# Altium schematic sheet DCDC_5V.SchDoc(DCDC_5V)
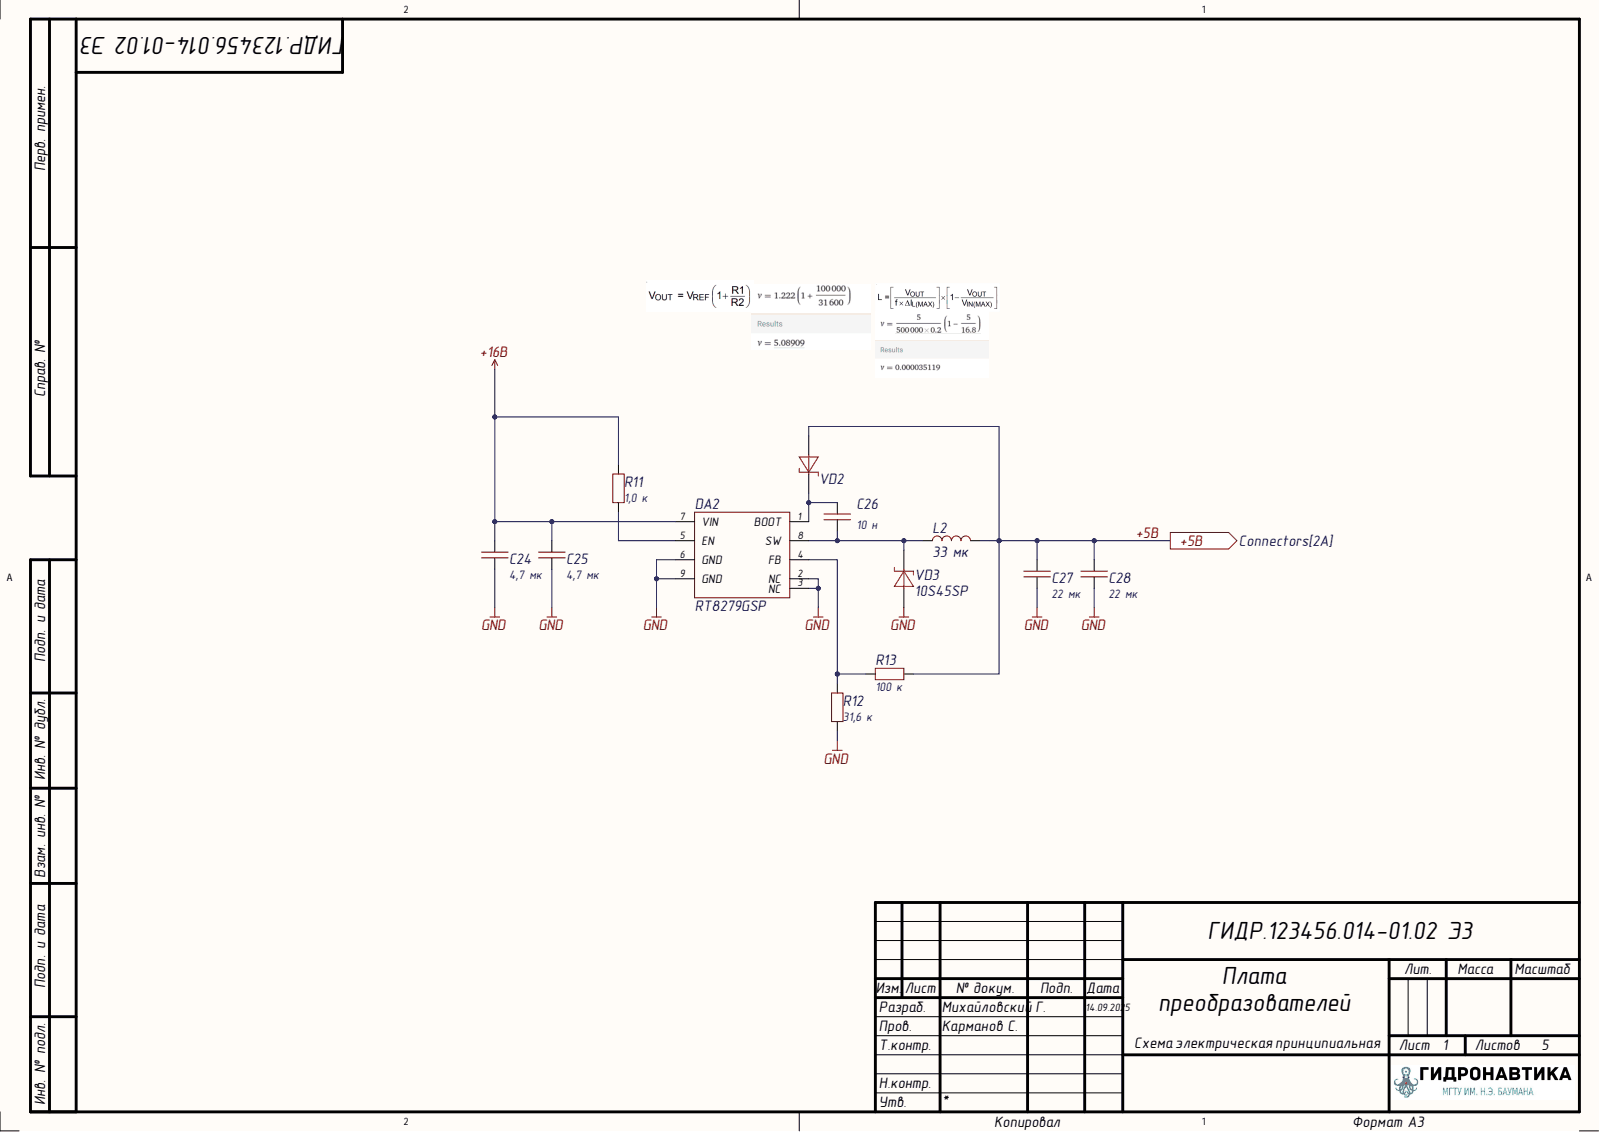
[diagram p0: DCDC_5V.SchDoc]

## Parts
C24  value 4,7 мк  [1206C]  (hidden comment: Capacitor)
C25  value 4,7 мк  [1206C]  (hidden comment: Capacitor)
C26  value 10 н  [0603C]  (hidden comment: Capacitor)
C27  value 22 мк  [1210C]  (hidden comment: Capacitor)
C28  value 22 мк  [1210C]  (hidden comment: Capacitor)
DA2  RT8279GSP  [8SOP(Exposed_Pad)]
L2  value 33 мк  [IHLP-4040]  (hidden comment: IHLP4040DZER330M11)
R11  value 1,0 к  [0603R]  (hidden comment: Resistor)
R12  value 31,6 к  [0603R]  (hidden comment: Resistor)
R13  value 100 к  [0603R]  (hidden comment: Resistor)
VD2  BAT54WS  [SOD-323]  (value not drawn)
VD3  10S45SP  [TO-277]

## Ports
+5В

## Nets
+16В: C24.2 C25.2 DA2.7(VIN) R11.2
GND: C24.1 C25.1 C27.1 C28.1 DA2.2(NC) DA2.3(NC) DA2.6(GND) DA2.9(GND) R12.1 VD3.1
+5В: C27.2 C28.2 L2.2 R13.2 VD2.1 ; port +5В
NetC26_1: C26.1 DA2.8(SW) L2.1 VD3.2
NetC26_2: C26.2 DA2.1(BOOT) VD2.2
NetDA2_4: DA2.4(FB) R12.2 R13.1
NetDA2_5: DA2.5(EN) R11.1

## Library data of the parts
C24 = Capacitor_4,7u_10_50V_X7R_1206C: Конденсатор; Capacity (nF): 4700; Database Table Name: Capacitors_ceramic$; Dielectric: X7R; Library Path2: Schematic\Capacitor.SchLib; Tolerance: 0,1; Value: 4,7 мк; Voltage (V): 50; Component Kind: Standard; Library Name: Hydrolib.DbLib; Part Number: Capacitor_4,7u_10_50V_X7R_1206C
C25 = Capacitor_4,7u_10_50V_X7R_1206C: Конденсатор; Capacity (nF): 4700; Database Table Name: Capacitors_ceramic$; Dielectric: X7R; Library Path2: Schematic\Capacitor.SchLib; Tolerance: 0,1; Voltage (V): 50; Component Kind: Standard; Library Name: Hydrolib.DbLib; Part Number: Capacitor_4,7u_10_50V_X7R_1206C
C26 = Capacitor_10n_10_50V_X7R_0603C: Конденсатор; Capacity (nF): 10; Database Table Name: Capacitors_ceramic$; Dielectric: X7R; Library Path2: Schematic\Capacitor.SchLib; Tolerance: 0,1; Voltage (V): 50; Component Kind: Standard; Library Name: Hydrolib.DbLib; Part Number: Capacitor_10n_10_50V_X7R_0603C
C27 = Capacitor_22u_10_25V_X7R_1210C: Конденсатор; Capacity (nF): 22000; Database Table Name: Capacitors_ceramic$; Dielectric: X7R; Library Path2: Schematic\Capacitor.SchLib; Tolerance: 0,1; Value: 22 мк; Voltage (V): 25; Component Kind: Standard; Library Name: Hydrolib.DbLib; Part Number: Capacitor_22u_10_25V_X7R_1210C
C28 = Capacitor_22u_10_25V_X7R_1210C: Конденсатор; Capacity (nF): 22000; Database Table Name: Capacitors_ceramic$; Dielectric: X7R; Library Path2: Schematic\Capacitor.SchLib; Tolerance: 0,1; Voltage (V): 25; Component Kind: Standard; Library Name: Hydrolib.DbLib; Part Number: Capacitor_22u_10_25V_X7R_1210C
DA2 = RT8279GSP: Преобразователь постоянного тока понижающий подстраиваемый выход 5А; Database Table Name: Chips$; Component Kind: Standard; Library Name: Hydrolib.DbLib; Part Number: RT8279GSP
L2 = IHLP4040DZER330M11: ЧИП-индуктивность экранированная 33мкГн ±20% 4,4A 94,5мОм 4040; Current (A): 4,4; Database Table Name: Inductance$; Inductance (μH): 33; Component Kind: Standard; Library Name: Hydrolib.DbLib; Part Number: IHLP4040DZER330M11
R11 = Resistor_1.0k_1_0603: Резистор номиналом 1,0 кОм и точностью 1%; Database Table Name: Resistors$; Component Kind: Standard; Library Name: Hydrolib.DbLib; Part Number: Resistor_1.0k_1_0603; Tolerance: 1%
R12 = Resistor_31.6k_1_0603: Резистор номиналом 31,6 кОм и точностью 1%; Database Table Name: Resistors$; Component Kind: Standard; Library Name: Hydrolib.DbLib; Part Number: Resistor_31.6k_1_0603; Tolerance: 1%
R13 = Resistor_100k_1_0603: Резистор номиналом 100 кОм и точностью 1%; Database Table Name: Resistors$; Component Kind: Standard; Library Name: Hydrolib.DbLib; Part Number: Resistor_100k_1_0603; Tolerance: 1%
VD2 = BAT54WS: Диод шоттки на 30 В, ном. ток 0.2 А; Current (A): 0.2; Database Table Name: Schottky$; Voltage nominal (V): 30; Component Kind: Standard; Library Name: Hydrolib.DbLib; Part Number: BAT54WS
VD3 = 10S45SP: Диод шоттки на 45 В, ном. ток 10 А; Current (A): 10; Database Table Name: Schottky$; Voltage nominal (V): 45; Component Kind: Standard; Library Name: Hydrolib.DbLib; Part Number: 10S45SP
